# Altium schematic sheet Jetson.SchDoc
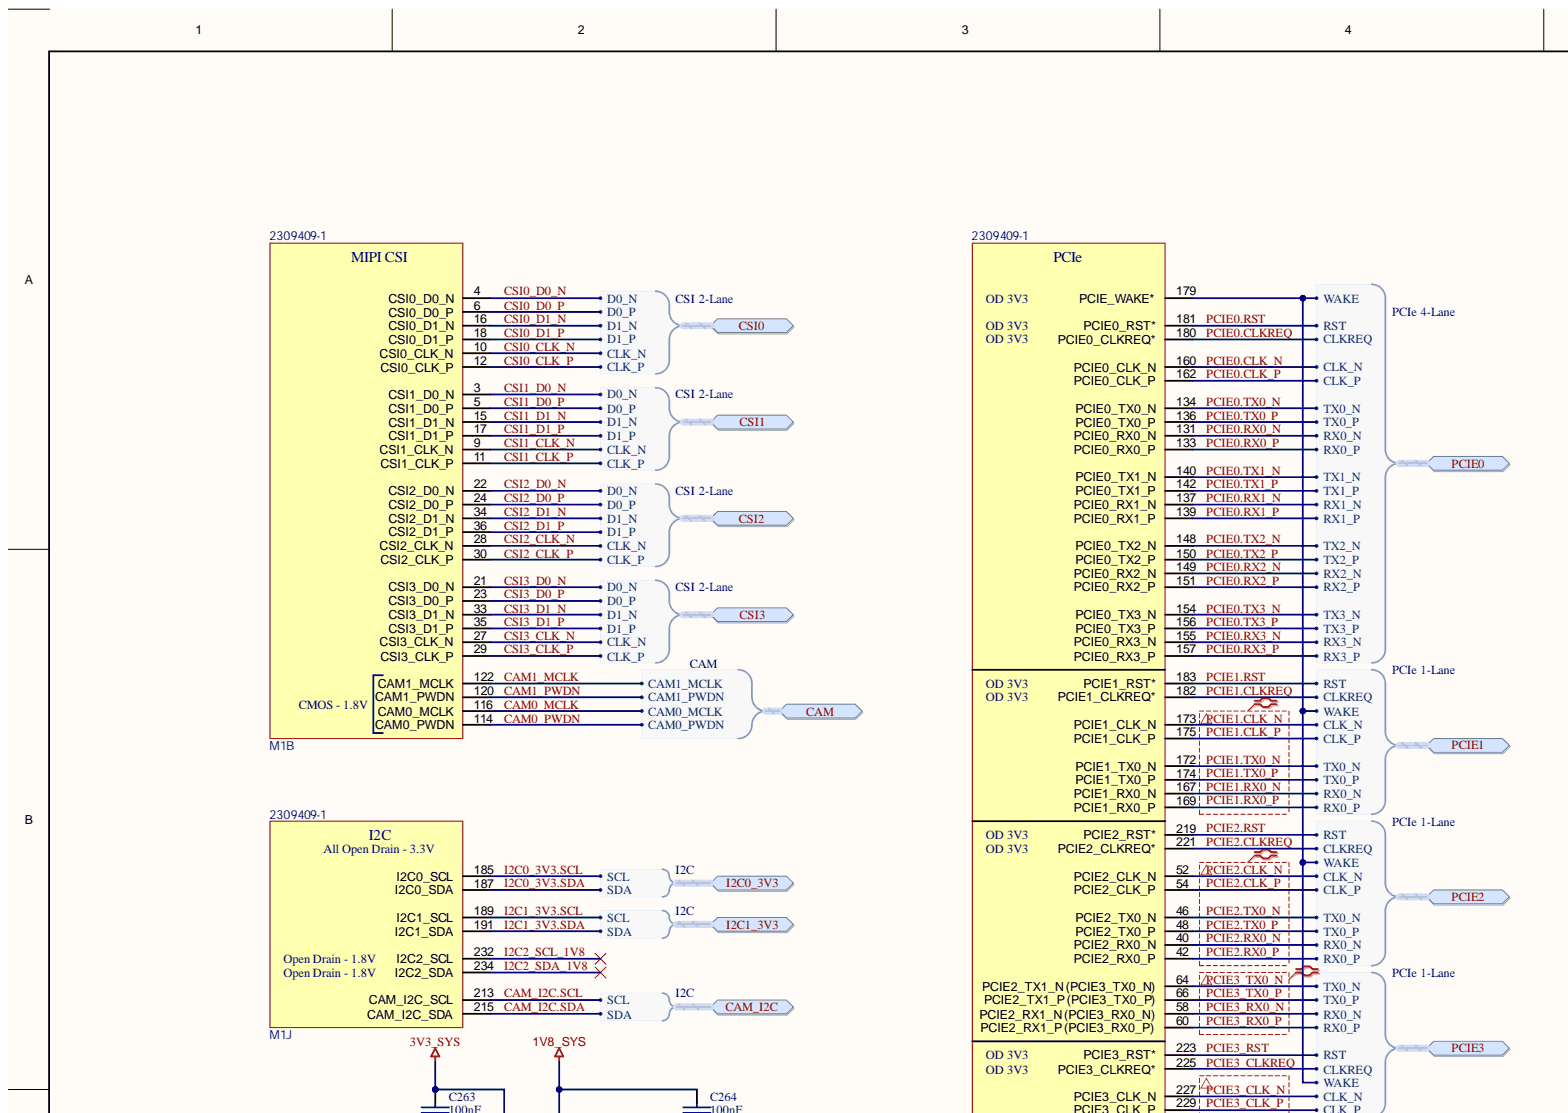
[diagram p0: Jetson.SchDoc, top left]
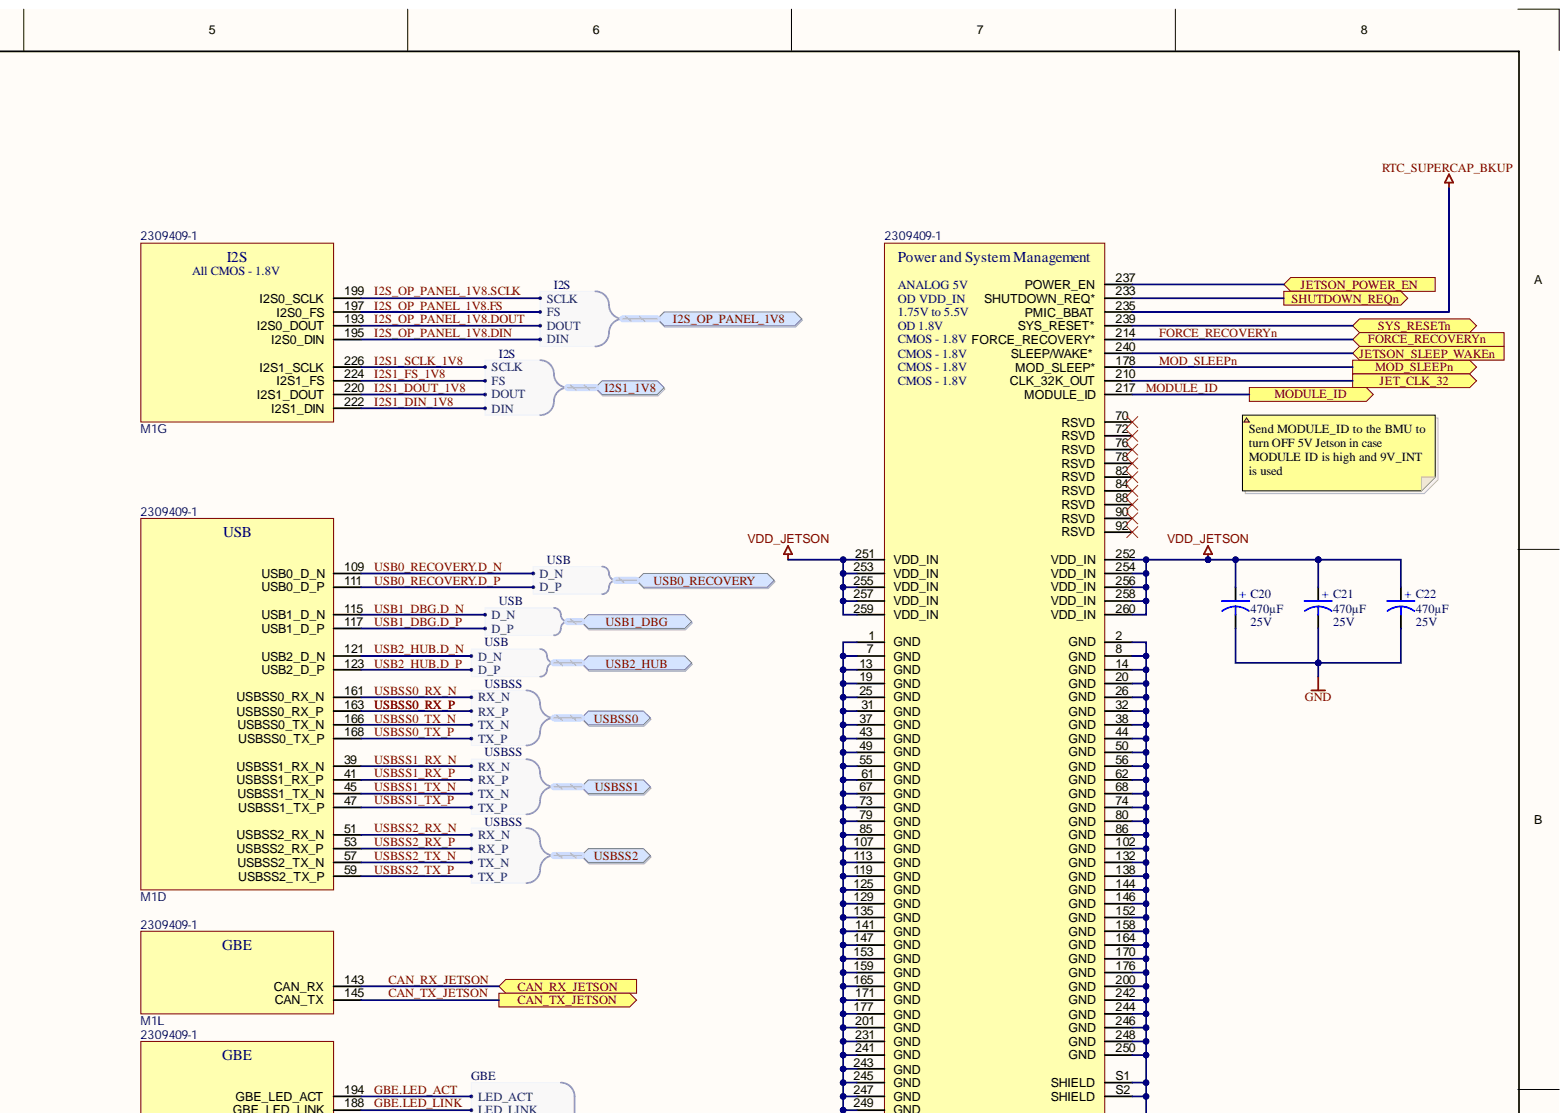
[diagram p1: Jetson.SchDoc, top right]
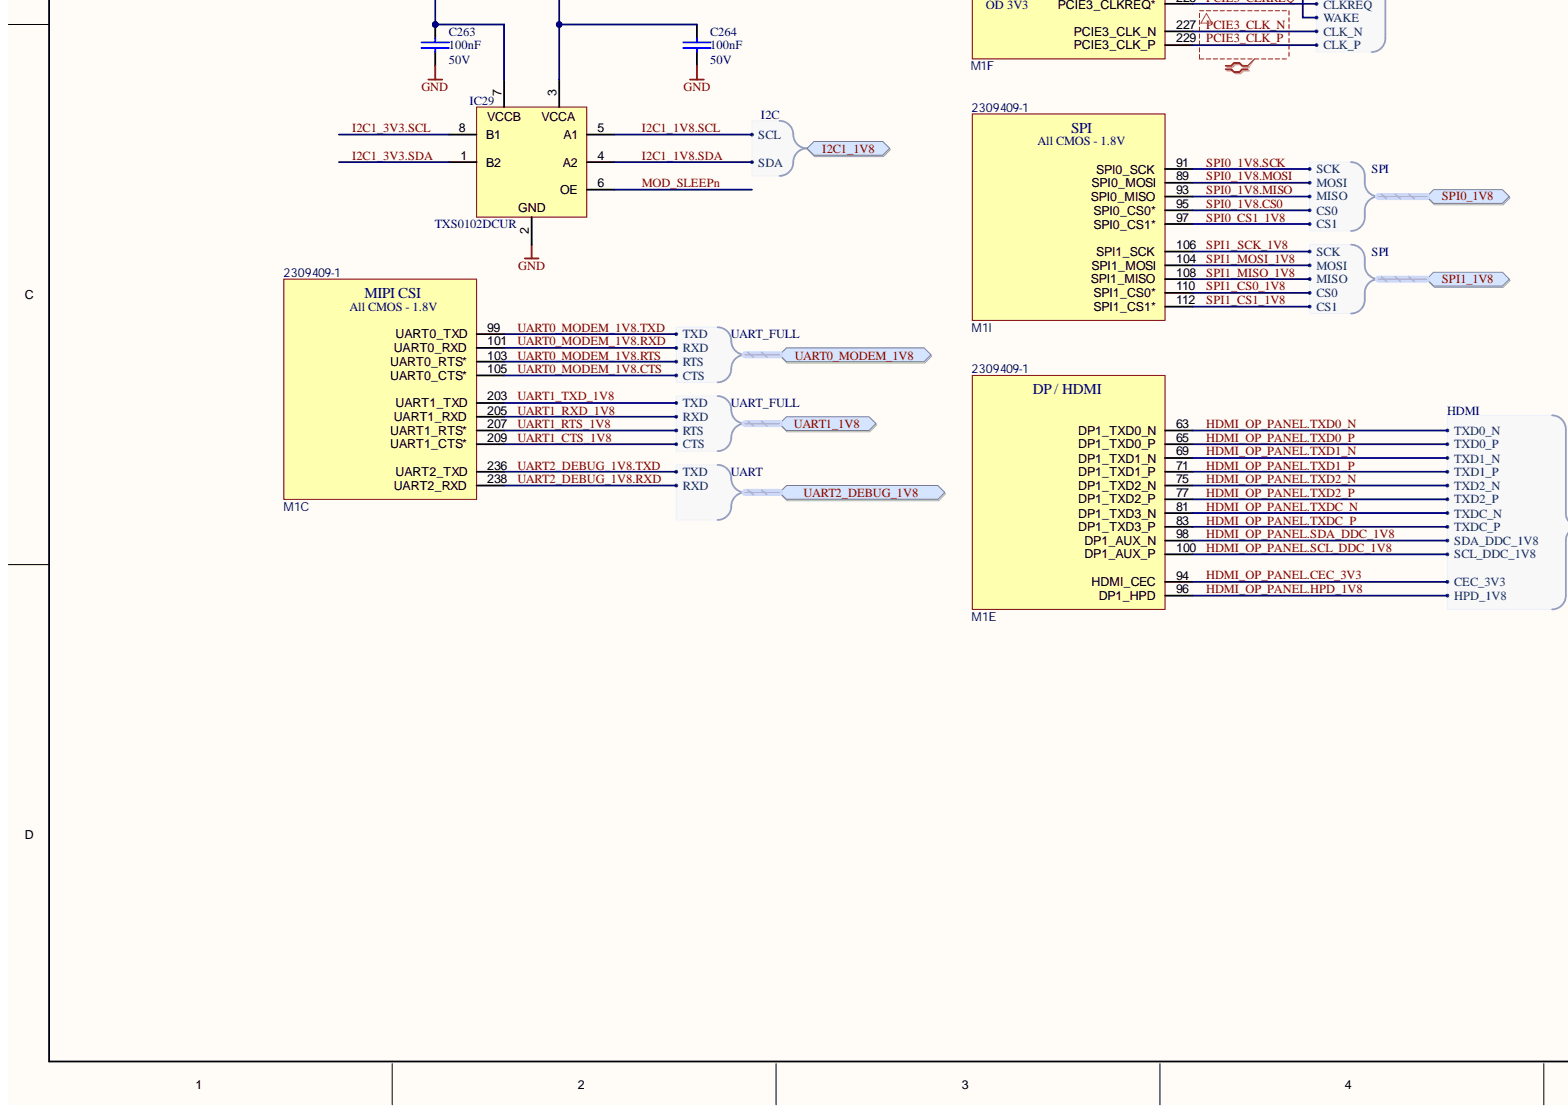
[diagram p2: Jetson.SchDoc, bottom left]
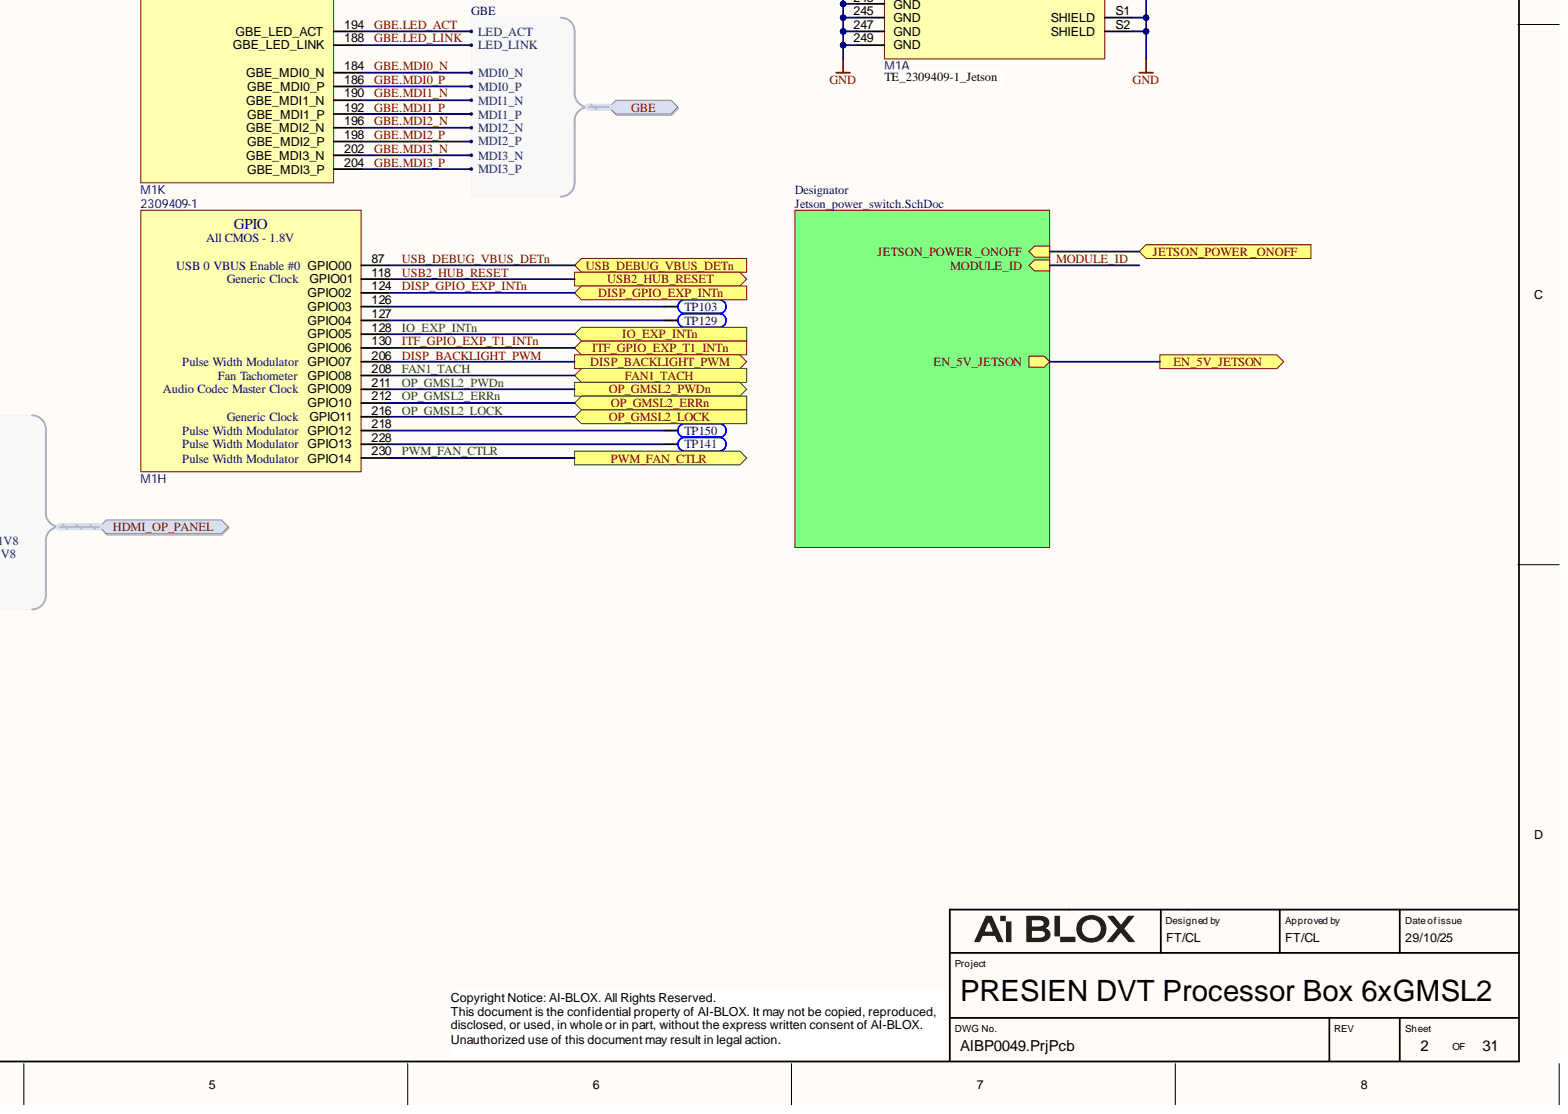
[diagram p3: Jetson.SchDoc, bottom right]

## Parts
C20  value 470µF  Voltage: 25V  [PANASONIC TCU SIZE G]  (hidden comment: CD1E477M10010VR2PA)
C21  value 470µF  Voltage: 25V  [PANASONIC TCU SIZE G]  (hidden comment: CD1E477M10010VR2PA)
C22  value 470µF  Voltage: 25V  [PANASONIC TCU SIZE G]  (hidden comment: CD1E477M10010VR2PA)
C263  value 100nF  Voltage: 50V  [CAP0402-M]  (hidden comment: GRM155C71H104KE19)
C264  value 100nF  Voltage: 50V  [CAP0402-M]  (hidden comment: GRM155C71H104KE19)
IC29  TXS0102DCUR  [TSSOP50P310X90-8N]
M1  2309409-1  [TE_2309409-1_Jetson]  (parts A,B,C,D,E,F,G,H,I,J,K,L)
TP103  Test_Point_1  [Test_Point_1]  (value not drawn)  (no BOM)
TP129  Test_Point_1  [Test_Point_1]  (value not drawn)  (no BOM)
TP141  Test_Point_1  [Test_Point_1]  (value not drawn)  (no BOM)
TP150  Test_Point_1  [Test_Point_1]  (value not drawn)  (no BOM)

## Ports
CAM, CAM.CAM0_MCLK, CAM.CAM0_PWDN, CAM.CAM1_MCLK, CAM.CAM1_PWDN, CAM_I2C, CAM_I2C.SCL, CAM_I2C.SDA, CAN_RX_JETSON, CAN_TX_JETSON, CSI0, CSI0.CLK_N, CSI0.CLK_P, CSI0.D0_N, CSI0.D0_P, CSI0.D1_N, CSI0.D1_P, CSI1, CSI1.CLK_N, CSI1.CLK_P, CSI1.D0_N, CSI1.D0_P, CSI1.D1_N, CSI1.D1_P, CSI2, CSI2.CLK_N, CSI2.CLK_P, CSI2.D0_N, CSI2.D0_P, CSI2.D1_N, CSI2.D1_P, CSI3, CSI3.CLK_N, CSI3.CLK_P, CSI3.D0_N, CSI3.D0_P, CSI3.D1_N, CSI3.D1_P, DISP_BACKLIGHT_PWM, DISP_GPIO_EXP_INTn, EN_5V_JETSON, FAN1_TACH, FORCE_RECOVERYn, GBE, GBE.LED_ACT, GBE.LED_LINK, GBE.MDI0_N, GBE.MDI0_P, GBE.MDI1_N, GBE.MDI1_P, GBE.MDI2_N, GBE.MDI2_P, GBE.MDI3_N, GBE.MDI3_P, HDMI_OP_PANEL, HDMI_OP_PANEL.CEC_3V3, HDMI_OP_PANEL.HPD_1V8, HDMI_OP_PANEL.SCL_DDC_1V8, HDMI_OP_PANEL.SDA_DDC_1V8, HDMI_OP_PANEL.TXD0_N, HDMI_OP_PANEL.TXD0_P, HDMI_OP_PANEL.TXD1_N, HDMI_OP_PANEL.TXD1_P, HDMI_OP_PANEL.TXD2_N, HDMI_OP_PANEL.TXD2_P, HDMI_OP_PANEL.TXDC_N, HDMI_OP_PANEL.TXDC_P, I2C0_3V3, I2C0_3V3.SCL, I2C0_3V3.SDA, I2C1_1V8, I2C1_1V8.SCL, I2C1_1V8.SDA, I2C1_3V3, I2C1_3V3.SCL, I2C1_3V3.SDA, I2S1_1V8, I2S1_1V8.DIN, I2S1_1V8.DOUT, I2S1_1V8.FS, I2S1_1V8.SCLK, I2S_OP_PANEL_1V8, I2S_OP_PANEL_1V8.DIN, I2S_OP_PANEL_1V8.DOUT, I2S_OP_PANEL_1V8.FS, I2S_OP_PANEL_1V8.SCLK, IO_EXP_INTn, ITF_GPIO_EXP_T1_INTn, JET_CLK_32, JETSON_POWER _ONOFF, JETSON_POWER_EN, JETSON_SLEEP_WAKEn, MOD_SLEEPn, MODULE_ID, OP_GMSL2_ERRn, OP_GMSL2_LOCK, OP_GMSL2_PWDn, PCIE0, PCIE0.CLK_N, PCIE0.CLK_P, PCIE0.CLKREQ, PCIE0.RST, PCIE0.RX0_N, PCIE0.RX0_P, PCIE0.RX1_N, PCIE0.RX1_P, PCIE0.RX2_N, PCIE0.RX2_P, PCIE0.RX3_N, PCIE0.RX3_P, PCIE0.TX0_N, PCIE0.TX0_P, PCIE0.TX1_N, PCIE0.TX1_P, PCIE0.TX2_N, PCIE0.TX2_P, PCIE0.TX3_N, PCIE0.TX3_P, PCIE0.WAKE, PCIE1, PCIE1.CLK_N, PCIE1.CLK_P, PCIE1.CLKREQ, PCIE1.RST, PCIE1.RX0_N, PCIE1.RX0_P, PCIE1.TX0_N, PCIE1.TX0_P, PCIE1.WAKE, PCIE2, PCIE2.CLK_N, PCIE2.CLK_P, PCIE2.CLKREQ, PCIE2.RST, PCIE2.RX0_N, PCIE2.RX0_P, PCIE2.TX0_N, PCIE2.TX0_P, PCIE2.WAKE, PCIE3, PCIE3.CLK_N, PCIE3.CLK_P, PCIE3.CLKREQ, PCIE3.RST, PCIE3.RX0_N, PCIE3.RX0_P, PCIE3.TX0_N, PCIE3.TX0_P, PCIE3.WAKE, PWM_FAN_CTLR, SHUTDOWN_REQn, SPI0_1V8, SPI0_1V8.CS0, SPI0_1V8.CS1, SPI0_1V8.MISO, SPI0_1V8.MOSI, SPI0_1V8.SCK, SPI1_1V8, SPI1_1V8.CS0, SPI1_1V8.CS1, SPI1_1V8.MISO, SPI1_1V8.MOSI, SPI1_1V8.SCK, SYS_RESETn, UART0_MODEM_1V8, UART0_MODEM_1V8.CTS, UART0_MODEM_1V8.RTS, UART0_MODEM_1V8.RXD, UART0_MODEM_1V8.TXD, UART1_1V8, UART1_1V8.CTS, UART1_1V8.RTS, UART1_1V8.RXD, UART1_1V8.TXD, UART2_DEBUG_1V8, UART2_DEBUG_1V8.RXD, UART2_DEBUG_1V8.TXD, USB0_RECOVERY, USB0_RECOVERY.D_N, USB0_RECOVERY.D_P, USB1_DBG, USB1_DBG.D_N, USB1_DBG.D_P, USB2_HUB, USB2_HUB.D_N, USB2_HUB.D_P, USB2_HUB_RESET, USB_DEBUG_VBUS_DETn, USBSS0, USBSS0.RX_N, USBSS0.RX_P, USBSS0.TX_N, USBSS0.TX_P, USBSS1, USBSS1.RX_N, USBSS1.RX_P, USBSS1.TX_N, USBSS1.TX_P, USBSS2, USBSS2.RX_N, USBSS2.RX_P, USBSS2.TX_N, USBSS2.TX_P

## Nets
1V8_SYS: C264.1 IC29.3
3V3_SYS: C263.1 IC29.7
GND: C20.- C21.- C22.- C263.2 C264.2 IC29.2 M1.1(GND) M1.2(GND) M1.7(GND) M1.8(GND) M1.13(GND) M1.14(GND) M1.19(GND) M1.20(GND) M1.25(GND) M1.26(GND) M1.31(GND) M1.32(GND) M1.37(GND) M1.38(GND) M1.43(GND) M1.44(GND) M1.49(GND) M1.50(GND) M1.55(GND) M1.56(GND) M1.61(GND) M1.62(GND) M1.67(GND) M1.68(GND) M1.73(GND) M1.74(GND) M1.79(GND) M1.80(GND) M1.85(GND) M1.86(GND) M1.102(GND) M1.107(GND) M1.113(GND) M1.119(GND) M1.125(GND) M1.129(GND) M1.132(GND) M1.135(GND) M1.138(GND) M1.141(GND) M1.144(GND) M1.146(GND) M1.147(GND) M1.152(GND) M1.153(GND) M1.158(GND) M1.159(GND) M1.164(GND) M1.165(GND) M1.170(GND) M1.171(GND) M1.176(GND) M1.177(GND) M1.200(GND) M1.201(GND) M1.231(GND) M1.241(GND) M1.242(GND) M1.243(GND) M1.244(GND) M1.245(GND) M1.246(GND) M1.247(GND) M1.248(GND) M1.249(GND) M1.250(GND) M1.S1(SHIELD) M1.S2(SHIELD)
RTC_SUPERCAP_BKUP: M1.235(PMIC_BBAT)
VDD_JETSON: C20.+ C21.+ C22.+ M1.251(VDD_IN) M1.252(VDD_IN) M1.253(VDD_IN) M1.254(VDD_IN) M1.255(VDD_IN) M1.256(VDD_IN) M1.257(VDD_IN) M1.258(VDD_IN) M1.259(VDD_IN) M1.260(VDD_IN)
CAM0_MCLK: M1.116(CAM0_MCLK) ; port CAM
CAM0_PWDN: M1.114(CAM0_PWDN) ; port CAM
CAM1_MCLK: M1.122(CAM1_MCLK) ; port CAM
CAM1_PWDN: M1.120(CAM1_PWDN) ; port CAM
CAM_I2C.SCL: M1.213(CAM_I2C_SCL) ; port CAM_I2C
CAM_I2C.SDA: M1.215(CAM_I2C_SDA) ; port CAM_I2C
CAN_RX_JETSON: M1.143(CAN_RX) ; port CAN_RX_JETSON
CAN_TX_JETSON: M1.145(CAN_TX) ; port CAN_TX_JETSON
CSI0_CLK_N: M1.10(CSI0_CLK_N) ; port CSI0
CSI0_CLK_P: M1.12(CSI0_CLK_P) ; port CSI0
CSI0_D0_N: M1.4(CSI0_D0_N) ; port CSI0
CSI0_D0_P: M1.6(CSI0_D0_P) ; port CSI0
CSI0_D1_N: M1.16(CSI0_D1_N) ; port CSI0
CSI0_D1_P: M1.18(CSI0_D1_P) ; port CSI0
CSI1_CLK_N: M1.9(CSI1_CLK_N) ; port CSI1
CSI1_CLK_P: M1.11(CSI1_CLK_P) ; port CSI1
CSI1_D0_N: M1.3(CSI1_D0_N) ; port CSI1
CSI1_D0_P: M1.5(CSI1_D0_P) ; port CSI1
CSI1_D1_N: M1.15(CSI1_D1_N) ; port CSI1
CSI1_D1_P: M1.17(CSI1_D1_P) ; port CSI1
CSI2_CLK_N: M1.28(CSI2_CLK_N) ; port CSI2
CSI2_CLK_P: M1.30(CSI2_CLK_P) ; port CSI2
CSI2_D0_N: M1.22(CSI2_D0_N) ; port CSI2
CSI2_D0_P: M1.24(CSI2_D0_P) ; port CSI2
CSI2_D1_N: M1.34(CSI2_D1_N) ; port CSI2
CSI2_D1_P: M1.36(CSI2_D1_P) ; port CSI2
CSI3_CLK_N: M1.27(CSI3_CLK_N) ; port CSI3
CSI3_CLK_P: M1.29(CSI3_CLK_P) ; port CSI3
CSI3_D0_N: M1.21(CSI3_D0_N) ; port CSI3
CSI3_D0_P: M1.23(CSI3_D0_P) ; port CSI3
CSI3_D1_N: M1.33(CSI3_D1_N) ; port CSI3
CSI3_D1_P: M1.35(CSI3_D1_P) ; port CSI3
DISP_BACKLIGHT_PWM: M1.206(GPIO07) ; port DISP_BACKLIGHT_PWM
DISP_GPIO_EXP_INTn: M1.124(GPIO02) ; port DISP_GPIO_EXP_INTn
FAN1_TACH: M1.208(GPIO08) ; port FAN1_TACH
FORCE_RECOVERYn: M1.214(CMOS_-_1.8V_FORCE_RECOVERY*) ; port FORCE_RECOVERYn
GBE.LED_ACT: M1.194(GBE_LED_ACT) ; port GBE
GBE.LED_LINK: M1.188(GBE_LED_LINK) ; port GBE
GBE.MDI0_N: M1.184(GBE_MDI0_N) ; port GBE
GBE.MDI0_P: M1.186(GBE_MDI0_P) ; port GBE
GBE.MDI1_N: M1.190(GBE_MDI1_N) ; port GBE
GBE.MDI1_P: M1.192(GBE_MDI1_P) ; port GBE
GBE.MDI2_N: M1.196(GBE_MDI2_N) ; port GBE
GBE.MDI2_P: M1.198(GBE_MDI2_P) ; port GBE
GBE.MDI3_N: M1.202(GBE_MDI3_N) ; port GBE
GBE.MDI3_P: M1.204(GBE_MDI3_P) ; port GBE
HDMI_OP_PANEL.CEC_3V3: M1.94(HDMI_CEC) ; port HDMI_OP_PANEL
HDMI_OP_PANEL.HPD_1V8: M1.96(DP1_HPD) ; port HDMI_OP_PANEL
HDMI_OP_PANEL.SCL_DDC_1V8: M1.100(DP1_AUX_P) ; port HDMI_OP_PANEL
HDMI_OP_PANEL.SDA_DDC_1V8: M1.98(DP1_AUX_N) ; port HDMI_OP_PANEL
HDMI_OP_PANEL.TXD0_N: M1.63(DP1_TXD0_N) ; port HDMI_OP_PANEL
HDMI_OP_PANEL.TXD0_P: M1.65(DP1_TXD0_P) ; port HDMI_OP_PANEL
HDMI_OP_PANEL.TXD1_N: M1.69(DP1_TXD1_N) ; port HDMI_OP_PANEL
HDMI_OP_PANEL.TXD1_P: M1.71(DP1_TXD1_P) ; port HDMI_OP_PANEL
HDMI_OP_PANEL.TXD2_N: M1.75(DP1_TXD2_N) ; port HDMI_OP_PANEL
HDMI_OP_PANEL.TXD2_P: M1.77(DP1_TXD2_P) ; port HDMI_OP_PANEL
HDMI_OP_PANEL.TXDC_N: M1.81(DP1_TXD3_N) ; port HDMI_OP_PANEL
HDMI_OP_PANEL.TXDC_P: M1.83(DP1_TXD3_P) ; port HDMI_OP_PANEL
I2C0_3V3.SCL: M1.185(I2C0_SCL) ; port I2C0_3V3
I2C0_3V3.SDA: M1.187(I2C0_SDA) ; port I2C0_3V3
I2C1_1V8.SCL: IC29.5(A1) ; port I2C1_1V8
I2C1_1V8.SDA: IC29.4(A2) ; port I2C1_1V8
I2C1_3V3.SCL: IC29.8(B1) M1.189(I2C1_SCL) ; port I2C1_3V3
I2C1_3V3.SDA: IC29.1(B2) M1.191(I2C1_SDA) ; port I2C1_3V3
I2C2_SCL_1V8: M1.232(I2C2_SCL)
I2C2_SDA_1V8: M1.234(I2C2_SDA)
I2S1_DIN_1V8: M1.222(I2S1_DIN) ; port I2S1_1V8
I2S1_DOUT_1V8: M1.220(I2S1_DOUT) ; port I2S1_1V8
I2S1_FS_1V8: M1.224(I2S1_FS) ; port I2S1_1V8
I2S1_SCLK_1V8: M1.226(I2S1_SCLK) ; port I2S1_1V8
I2S_OP_PANEL_1V8.DIN: M1.195(I2S0_DIN) ; port I2S_OP_PANEL_1V8
I2S_OP_PANEL_1V8.DOUT: M1.193(I2S0_DOUT) ; port I2S_OP_PANEL_1V8
I2S_OP_PANEL_1V8.FS: M1.197(I2S0_FS) ; port I2S_OP_PANEL_1V8
I2S_OP_PANEL_1V8.SCLK: M1.199(I2S0_SCLK) ; port I2S_OP_PANEL_1V8
IO_EXP_INTn: M1.128(GPIO05) ; port IO_EXP_INTn
ITF_GPIO_EXP_T1_INTn: M1.130(GPIO06) ; port ITF_GPIO_EXP_T1_INTn
JET_CLK_32: M1.210(CLK_32K_OUT) ; port JET_CLK_32
JETSON_POWER_EN: M1.237(POWER_EN) ; port JETSON_POWER_EN
JETSON_SLEEP_WAKEn: M1.240(SLEEP/WAKE*) ; port JETSON_SLEEP_WAKEn
MOD_SLEEPn: IC29.6(OE) M1.178(MOD_SLEEP*) ; port MOD_SLEEPn
MODULE_ID: M1.217(MODULE_ID) ; port MODULE_ID
OP_GMSL2_ERRn: M1.212(GPIO10) ; port OP_GMSL2_ERRn
OP_GMSL2_LOCK: M1.216(GPIO11) ; port OP_GMSL2_LOCK
OP_GMSL2_PWDn: M1.211(GPIO09) ; port OP_GMSL2_PWDn
PCIE0: M1.179(PCIE_WAKE*) ; port PCIE0, port PCIE1, port PCIE2, port PCIE3
PCIE0.CLK_N: M1.160(PCIE0_CLK_N) ; port PCIE0
PCIE0.CLK_P: M1.162(PCIE0_CLK_P) ; port PCIE0
PCIE0.CLKREQ: M1.180(PCIE0_CLKREQ*) ; port PCIE0
PCIE0.RST: M1.181(PCIE0_RST*) ; port PCIE0
PCIE0.RX0_N: M1.131(PCIE0_RX0_N) ; port PCIE0
PCIE0.RX0_P: M1.133(PCIE0_RX0_P) ; port PCIE0
PCIE0.RX1_N: M1.137(PCIE0_RX1_N) ; port PCIE0
PCIE0.RX1_P: M1.139(PCIE0_RX1_P) ; port PCIE0
PCIE0.RX2_N: M1.149(PCIE0_RX2_N) ; port PCIE0
PCIE0.RX2_P: M1.151(PCIE0_RX2_P) ; port PCIE0
PCIE0.RX3_N: M1.155(PCIE0_RX3_N) ; port PCIE0
PCIE0.RX3_P: M1.157(PCIE0_RX3_P) ; port PCIE0
PCIE0.TX0_N: M1.134(PCIE0_TX0_N) ; port PCIE0
PCIE0.TX0_P: M1.136(PCIE0_TX0_P) ; port PCIE0
PCIE0.TX1_N: M1.140(PCIE0_TX1_N) ; port PCIE0
PCIE0.TX1_P: M1.142(PCIE0_TX1_P) ; port PCIE0
PCIE0.TX2_N: M1.148(PCIE0_TX2_N) ; port PCIE0
PCIE0.TX2_P: M1.150(PCIE0_TX2_P) ; port PCIE0
PCIE0.TX3_N: M1.154(PCIE0_TX3_N) ; port PCIE0
PCIE0.TX3_P: M1.156(PCIE0_TX3_P) ; port PCIE0
PCIE1.CLK_N: M1.173(PCIE1_CLK_N) ; port PCIE1
PCIE1.CLK_P: M1.175(PCIE1_CLK_P) ; port PCIE1
PCIE1.CLKREQ: M1.182(PCIE1_CLKREQ*) ; port PCIE1
PCIE1.RST: M1.183(PCIE1_RST*) ; port PCIE1
PCIE1.RX0_N: M1.167(PCIE1_RX0_N) ; port PCIE1
PCIE1.RX0_P: M1.169(PCIE1_RX0_P) ; port PCIE1
PCIE1.TX0_N: M1.172(PCIE1_TX0_N) ; port PCIE1
PCIE1.TX0_P: M1.174(PCIE1_TX0_P) ; port PCIE1
PCIE2.CLK_N: M1.52(PCIE2_CLK_N) ; port PCIE2
PCIE2.CLK_P: M1.54(PCIE2_CLK_P) ; port PCIE2
PCIE2.CLKREQ: M1.221(PCIE2_CLKREQ*) ; port PCIE2
PCIE2.RST: M1.219(PCIE2_RST*) ; port PCIE2
PCIE2.RX0_N: M1.40(PCIE2_RX0_N) ; port PCIE2
PCIE2.RX0_P: M1.42(PCIE2_RX0_P) ; port PCIE2
PCIE2.TX0_N: M1.46(PCIE2_TX0_N) ; port PCIE2
PCIE2.TX0_P: M1.48(PCIE2_TX0_P) ; port PCIE2
PCIE3_CLK_N: M1.227(PCIE3_CLK_N) ; port PCIE3
PCIE3_CLK_P: M1.229(PCIE3_CLK_P) ; port PCIE3
PCIE3_CLKREQ: M1.225(PCIE3_CLKREQ*) ; port PCIE3
PCIE3_RST: M1.223(PCIE3_RST*) ; port PCIE3
PCIE3_RX0_N: M1.58(PCIE2_RX1_N_(PCIE3_RX0_N)) ; port PCIE3
PCIE3_RX0_P: M1.60(PCIE2_RX1_P_(PCIE3_RX0_P)) ; port PCIE3
PCIE3_TX0_N: M1.64(PCIE2_TX1_N_(PCIE3_TX0_N)) ; port PCIE3
PCIE3_TX0_P: M1.66(PCIE2_TX1_P_(PCIE3_TX0_P)) ; port PCIE3
PWM_FAN_CTLR: M1.230(GPIO14) ; port PWM_FAN_CTLR
SHUTDOWN_REQn: M1.233(SHUTDOWN_REQ*) ; port SHUTDOWN_REQn
SPI0_1V8.CS0: M1.95(SPI0_CS0*) ; port SPI0_1V8
SPI0_1V8.MISO: M1.93(SPI0_MISO) ; port SPI0_1V8
SPI0_1V8.MOSI: M1.89(SPI0_MOSI) ; port SPI0_1V8
SPI0_1V8.SCK: M1.91(SPI0_SCK) ; port SPI0_1V8
SPI0_CS1_1V8: M1.97(SPI0_CS1*) ; port SPI0_1V8
SPI1_CS0_1V8: M1.110(SPI1_CS0*) ; port SPI1_1V8
SPI1_CS1_1V8: M1.112(SPI1_CS1*) ; port SPI1_1V8
SPI1_MISO_1V8: M1.108(SPI1_MISO) ; port SPI1_1V8
SPI1_MOSI_1V8: M1.104(SPI1_MOSI) ; port SPI1_1V8
SPI1_SCK_1V8: M1.106(SPI1_SCK) ; port SPI1_1V8
SYS_RESETn: M1.239(SYS_RESET*) ; port SYS_RESETn
UART0_MODEM_1V8.CTS: M1.105(UART0_CTS*) ; port UART0_MODEM_1V8
UART0_MODEM_1V8.RTS: M1.103(UART0_RTS*) ; port UART0_MODEM_1V8
UART0_MODEM_1V8.RXD: M1.101(UART0_RXD) ; port UART0_MODEM_1V8
UART0_MODEM_1V8.TXD: M1.99(UART0_TXD) ; port UART0_MODEM_1V8
UART1_CTS_1V8: M1.209(UART1_CTS*) ; port UART1_1V8
UART1_RTS_1V8: M1.207(UART1_RTS*) ; port UART1_1V8
UART1_RXD_1V8: M1.205(UART1_RXD) ; port UART1_1V8
UART1_TXD_1V8: M1.203(UART1_TXD) ; port UART1_1V8
UART2_DEBUG_1V8.RXD: M1.238(UART2_RXD) ; port UART2_DEBUG_1V8
UART2_DEBUG_1V8.TXD: M1.236(UART2_TXD) ; port UART2_DEBUG_1V8
USB0_RECOVERY.D_N: M1.109(USB0_D_N) ; port USB0_RECOVERY
USB0_RECOVERY.D_P: M1.111(USB0_D_P) ; port USB0_RECOVERY
USB1_DBG.D_N: M1.115(USB1_D_N) ; port USB1_DBG
USB1_DBG.D_P: M1.117(USB1_D_P) ; port USB1_DBG
USB2_HUB.D_N: M1.121(USB2_D_N) ; port USB2_HUB
USB2_HUB.D_P: M1.123(USB2_D_P) ; port USB2_HUB
USB2_HUB_RESET: M1.118(GPIO01) ; port USB2_HUB_RESET
USB_DEBUG_VBUS_DETn: M1.87(GPIO00) ; port USB_DEBUG_VBUS_DETn
USBSS0_RX_N: M1.161(USBSS0_RX_N) ; port USBSS0
USBSS0_RX_P: M1.163(USBSS0_RX_P) ; port USBSS0
USBSS0_TX_N: M1.166(USBSS0_TX_N) ; port USBSS0
USBSS0_TX_P: M1.168(USBSS0_TX_P) ; port USBSS0
USBSS1_RX_N: M1.39(USBSS1_RX_N) ; port USBSS1
USBSS1_RX_P: M1.41(USBSS1_RX_P) ; port USBSS1
USBSS1_TX_N: M1.45(USBSS1_TX_N) ; port USBSS1
USBSS1_TX_P: M1.47(USBSS1_TX_P) ; port USBSS1
USBSS2_RX_N: M1.51(USBSS2_RX_N) ; port USBSS2
USBSS2_RX_P: M1.53(USBSS2_RX_P) ; port USBSS2
USBSS2_TX_N: M1.57(USBSS2_TX_N) ; port USBSS2
USBSS2_TX_P: M1.59(USBSS2_TX_P) ; port USBSS2
NetM1_126: M1.126(GPIO03) TP103.1
NetM1_127: M1.127(GPIO04) TP129.1
NetM1_218: M1.218(GPIO12) TP150.1
NetM1_228: M1.228(GPIO13) TP141.1
EN_5V_JETSON: ; port EN_5V_JETSON
JETSON_POWER _ONOFF: ; port JETSON_POWER _ONOFF

Not connected: M1 70(RSVD) 72(RSVD) 76(RSVD) 78(RSVD) 82(RSVD) 84(RSVD) 88(RSVD) 90(RSVD) 92(RSVD)

## Library data of the parts
C20-C22 = CMP-81.02.0034-3: CAP ALUM 470UF 20% 25V SMD; Manufacturer 1: Samwha; GRADE: NO; Case/Package: 10,3x10,3; Component Kind: Standard; DatasheetDocument: https://octopart.com/search?q=CD1E477M10010VR2PA&currency=USD&specs=0; Internal Ref: 81.02.0034; Manufacturer Part Number 1: CD1E477M10010VR2PA; ROHS: YES; StockLoc: R02/B05/L05; Tolerance: 20%; Library Name: AI Blox; Publisher: Hans Stevens
C263 C264 = CMP-81.02.0039-10: CAP CER 0.1UF 50V X7S 0402; Manufacturer 1: Murata; GRADE: NO; Tolerance: 10%; Case/Package: 0402; Component Kind: Standard; DatasheetDocument: https://octopart.com/datasheet/grm155c71h104ke19d-murata-18783362; Internal Ref: 81.02.0039; Manufacturer Part Number 1: GRM155C71H104KE19; ROHS: YES; Library Name: AI Blox; Publisher: Hans Stevens
IC29 = CMP-81.04.0159-6: IC TRANSLATOR BIDIRECTIONAL SM8; Manufacturer Part Number 1: Texas Instruments; GRADE: NO; Component Kind: Standard; DatasheetDocument: https://octopart.com/txs0102dcur-texas+instruments-5414308; Internal Ref: 81.04.0159; Manufacturer 1: TXS0102DCUR; Package: VSSOP8; ROHS: YES; Library Name: AI Blox; Publisher: Jeroen Wallyn
M1 = CMP-81.03.0058-3: DDR4 SODIMM 260P 5.2H STD; Manufacturer 1: TE Connectivity; GRADE: NO; Component Kind: Standard; DatasheetDocument: https://octopart.com/datasheet/2309409-1-te+connectivity-86978156; Internal Ref: 81.03.0058; Manufacturer Part Number 1: 2309409-1; ROHS: YES; Library Name: AI Blox; Publisher: Hans Stevens
TP103 TP129 TP141 TP150 = CMP-81.00.0006-9: 0.8mm testpoint; Library Name: AI Blox
